# Altium schematic sheet 06-I2C.SchDoc
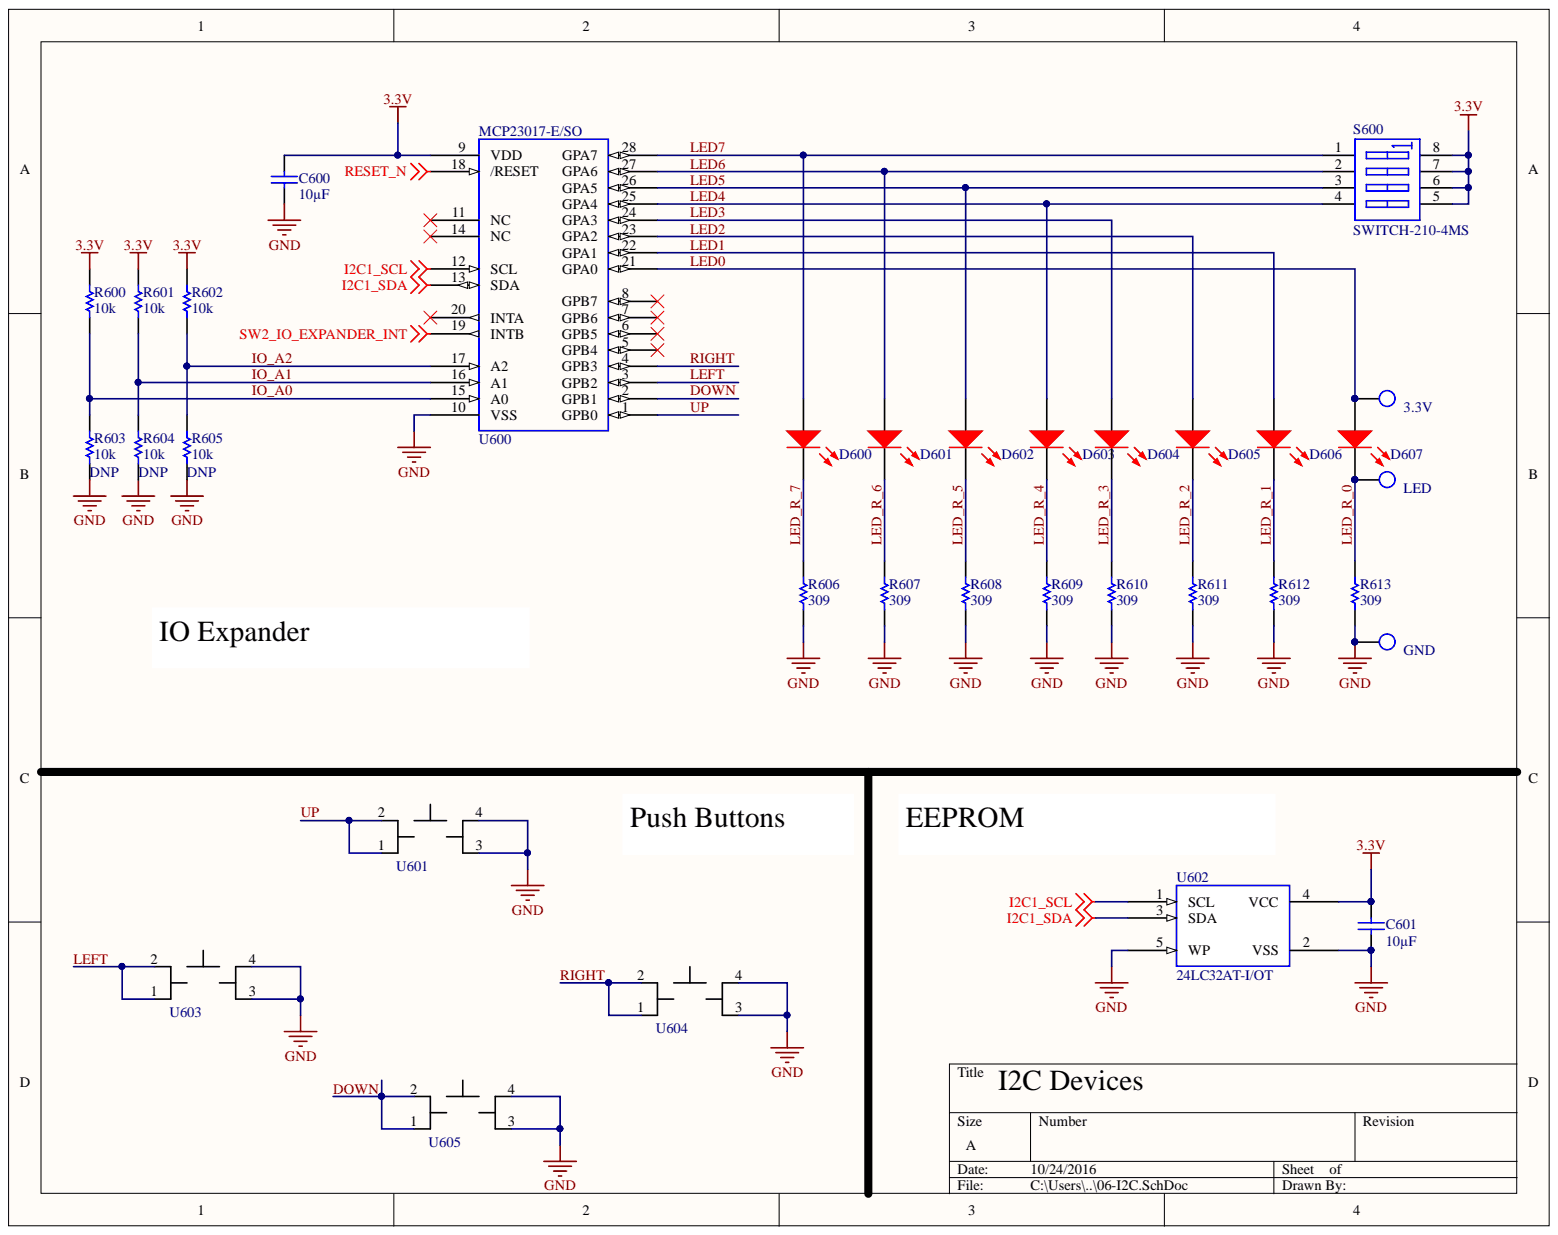
[diagram p0: 06-I2C.SchDoc]

## Parts
C600  10µF  [0805]
C601  10µF  [0805]
D600  LED_RED_0805  [CD2012-0805]  (value not drawn)
D601  LED_RED_0805  [CD2012-0805]  (value not drawn)
D602  LED_RED_0805  [CD2012-0805]  (value not drawn)
D603  LED_RED_0805  [CD2012-0805]  (value not drawn)
D604  LED_RED_0805  [CD2012-0805]  (value not drawn)
D605  LED_RED_0805  [CD2012-0805]  (value not drawn)
D606  LED_RED_0805  [CD2012-0805]  (value not drawn)
D607  LED_RED_0805  [CD2012-0805]  (value not drawn)
R600  10k  [RES_0805]
R601  10k  [RES_0805]
R602  10k  [RES_0805]
R603  10k  Usage: DNP  [RES_0805]
R604  10k  Usage: DNP  [RES_0805]
R605  10k  Usage: DNP  [RES_0805]
R606  309  [RES_0805]
R607  309  [RES_0805]
R608  309  [RES_0805]
R609  309  [RES_0805]
R610  309  [RES_0805]
R611  309  [RES_0805]
R612  309  [RES_0805]
R613  309  [RES_0805]
S600  SWITCH-210-4MS  [DIP-8]
TP600  3.3V  [TP-SMC-1206]
TP601  LED  [TP-SMC-1206]
TP602  GND  [TP-SMC-1206]
U600  Manufacturer Part Number: MCP23017-E/SO  [SOIC-28]  (hidden comment: IC_MCP23017)
U601  SWITCH_6mm_6mm_smd  [SWITCH_6mm_6mm_smd]  (value not drawn)
U602  Manufacturer Part Number: 24LC32AT-I/OT  [SOT23-5-MCP]  (hidden comment: IC_24LC32AT-I/OT)
U603  SWITCH_6mm_6mm_smd  [SWITCH_6mm_6mm_smd]  (value not drawn)
U604  SWITCH_6mm_6mm_smd  [SWITCH_6mm_6mm_smd]  (value not drawn)
U605  SWITCH_6mm_6mm_smd  [SWITCH_6mm_6mm_smd]  (value not drawn)

## Nets
3.3V: C600.2 C601.2 R600.2 R601.2 R602.2 S600.5 S600.6 S600.7 S600.8 U600.9(VDD) U602.4(VCC)
GND: C600.1 C601.1 R603.1 R604.1 R605.1 R606.1 R607.1 R608.1 R609.1 R610.1 R611.1 R612.1 R613.1 TP602.1 U600.10(VSS) U601.3 U601.4 U602.2(VSS) U602.5(WP) U603.3 U603.4 U604.3 U604.4 U605.3 U605.4
I2C1_SCL: U600.12(SCL) U602.1(SCL)
I2C1_SDA: U600.13(SDA) U602.3(SDA)
RESET_N: U600.18(/RESET)
SW2_IO_EXPANDER_INT: U600.19(INTB)
DOWN: U600.2(GPB1) U605.1 U605.2
IO_A0: R600.1 R603.2 U600.15(A0)
IO_A1: R601.1 R604.2 U600.16(A1)
IO_A2: R602.1 R605.2 U600.17(A2)
LED0: D607.1 TP600.1 U600.21(GPA0)
LED1: D606.1 U600.22(GPA1)
LED2: D605.1 U600.23(GPA2)
LED3: D604.1 U600.24(GPA3)
LED4: D603.1 S600.4 U600.25(GPA4)
LED5: D602.1 S600.3 U600.26(GPA5)
LED6: D601.1 S600.2 U600.27(GPA6)
LED7: D600.1 S600.1 U600.28(GPA7)
LED_R_0: D607.2 R613.2 TP601.1
LED_R_1: D606.2 R612.2
LED_R_2: D605.2 R611.2
LED_R_3: D604.2 R610.2
LED_R_4: D603.2 R609.2
LED_R_5: D602.2 R608.2
LED_R_6: D601.2 R607.2
LED_R_7: D600.2 R606.2
LEFT: U600.3(GPB2) U603.1 U603.2
RIGHT: U600.4(GPB3) U604.1 U604.2
UP: U600.1(GPB0) U601.1 U601.2

Not connected: U600 5(GPB4) 6(GPB5) 7(GPB6) 8(GPB7) 11(NC) 14(NC) 20(INTA)

## Library data of the parts
C600 C601 = CAP_0805_U_010.000: CAP CER 10UF 16V 10% X5R 0805; Manufacturer: Murata Electronics North America; Manufacturer Part Number: GRM21BR61C106KE15L; Applications: General Purpose; Capacitance: 10µF; Category: Capacitors; Component Kind: Standard; Features: -; Height - Seated (Max): -; Lead Spacing: -; Lead Style: -; Library Name: ece353-2016.SchLib; Mounting Type: Surface Mount, MLCC; Operating Temperature: -55°C ~ 85°C; Package / Case: 0805 (2012 Metric); Packaging: Cut Tape (CT); Ratings: -; RoHS: RoHS Compliant; Size / Dimension: 0.079" L x 0.049" W (2.00mm x 1.25mm); Supplier: Digi-Key; Supplier 1: Digi-Key; Supplier Part Number: 490-3886-1-ND; Supplier Part Number 1: 490-3886-1-ND; Temperature Coefficient: X5R; Thickness (Max): 0.053" (1.35mm); Tolerance: ±10%; Value: 10µF; Voltage - Rated: 16V
D600-D607 = LED_RED_0805: LED RED CLEAR 0805 SMD; Manufacturer: Kingbright; Manufacturer Part Number: APT3216SRCPRV; Current - Test: 20mA; Lens Color: Colorless; Lens Style/Size: Rectangle with Flat Top, 2.00mm x 1.60mm; Millicandela Rating: 30mcd; Viewing Angle: 120°; Voltage - Forward (Vf) (Typ): 1.85V; Wavelength - Dominant: 640nm; Wavelength - Peak: 655nm; Category: Optoelectronics; Color: Red; Component Kind: Standard; Features: -; Height (Max): 0.75mm; LatestRevisionDate: 17-Jul-2002; LatestRevisionNote: Re-released for DXP Platform.; Lens Transparency: Clear; Library Name: ece353-2016.SchLib; Mounting Type: Surface Mount; Package / Case: 1206 (3216 Metric); PackageDescription: SM LED; 2 Flat Leads; PackageReference: 3.2X1.6X1.1; PackageVersion: Feb-2002; Packaging: Cut Tape (CT); Published: 8-Jun-2000; RoHS: RoHS Compliant; Size / Dimension: 3.20mm L x 1.60mm W; Supplier: Digi-Key; Supplier 1: Digi-Key; Supplier Device Package: Chip LED; Supplier Part Number: 754-1142-1-ND; Supplier Part Number 1: 754-1097-1-ND; Publisher: Altium Limited
R600-R605 = RES_0805_K_010.00: RES 10K 1/10W 1% 0805 SMD; Manufacturer: Panasonic Electronic Components; Manufacturer Part Number: ERJ-6ENF1002V; Power (Watts): 0.125W, 1/8W; Temperature Coefficient: ±100ppm/°C; Category: Resistors; Component Kind: Standard; Composition: Thick Film; Features: Automotive AEC-Q200; Height: 0.028" (0.70mm); Library Name: ece353-2016.SchLib; Number of Terminations: 2; Operating Temperature: -55°C ~ 155°C; Package / Case: 0805 (2012 Metric); Packaging: Cut Tape (CT); Resistance: 10k; RoHS: RoHS Compliant; Size / Dimension: 0.079" L x 0.049" W (2.00mm x 1.25mm); Supplier: Digi-Key; Supplier 1: Digi-Key; Supplier Device Package: 0805; Supplier Part Number: P10.0KCCT-ND; Supplier Part Number 1: P10.0KCCT-ND; Tolerance: ±1%; Value: 10k
R606-R613 = RES_0805_309.00: RES 309 OHM 1% 1/8W 0805; Manufacturer: Panasonic Electronic Components; Manufacturer Part Number: ERJ-6ENF3090V; Power (Watts): 0.125W, 1/8W; Temperature Coefficient: ±100ppm/°C; Category: Resistors; Component Kind: Standard; Composition: Thick Film; Features: Automotive AEC-Q200; Height: 0.028" (0.70mm); Library Name: ece353-2016.SchLib; Number of Terminations: 2; Operating Temperature: -55°C ~ 155°C; Package / Case: 0805 (2012 Metric); Packaging: Cut Tape (CT); Resistance: 309; RoHS: RoHS Compliant; Size / Dimension: 0.079" L x 0.049" W (2.00mm x 1.25mm); Supplier: Digi-Key; Supplier 1: Digi-Key; Supplier Device Package: 0805; Supplier Part Number: P309CCT-ND; Supplier Part Number 1: P309CCT-ND; Tolerance: ±1%; Value: 309
S600 = SWITCH-210-4MS: DIP Switch, 4 Position, SPST; Manufacturer: CTS Electrocomponents; Actuator Level: Raised; Actuator Type: Slide (Standard); Current Rating: 100mA; Electrical Life: 1,000 Cycles; Number of Positions: 4; Pitch: 0.100" (2.54mm), Full; Voltage Rating: 20VDC; Washable: No; Body Material: -; Category: Switches; Circuit: SPST; Code_IPC: SOIC127P600-8; Code_JEDEC: MS-012-AA; Component Kind: Standard; Contact Finish: Gold; Contact Material: Beryllium Copper; Features: Epoxy Sealed Terminals; Height Above Board: 0.190" (4.83mm); LatestRevisionDate: 29-May-2009; LatestRevisionNote: IPC-7351 Footprint Added.; Length: 0.458" (11.63mm); Library Name: ece353-2016.SchLib; Manufacturer Part Number: 210-4MS; Mechanical Life: -; Mounting Type: Through Hole; Operating Temperature: -40°C ~ 85°C; PackageDescription: 8-Pin Small Outline Integrated Circuit 1.27 mm Pitch; PackageReference: SO8; PackageVersion: Dec-2002; Packaging: Tube; Published: 24-Mar-1999; RoHS: RoHS Compliant; Supplier: Digi-Key; Supplier 1: Digi-Key; Supplier Part Number: CT2104MS-ND; Supplier Part Number 1: CT2104MS-ND; Termination Style: PC Pin; Publisher: Altium Limited
TP600-TP602 = TP_1206: Test Point SMD 1206; Component Kind: Standard; Library Name: ece353-2016.SchLib; Supplier 1: Digi-Key; Supplier Part Number 1: A106143CT-ND
U600 = IC_MCP23017: I2C 16-bit IO Expander; Manufacturer: Microchip Technology; Current - Output Source/Sink: 25mA; Frequency - Clock: 1.7MHz; Interface: I²C; Interrupt Output: Yes; Number of I/O: 16; Output Type: Push-Pull; Voltage - Supply: 1.8 V ~ 5.5 V; Category: Integrated Circuits (ICs); Component Kind: Standard; Features: POR; Library Name: ece353-2016.SchLib; Mounting Type: Surface Mount; Operating Temperature: -40°C ~ 125°C; Package / Case: 28-SOIC (0.295", 7.50mm Width); Packaging: Tube; RoHS: RoHS Compliant; Supplier 1: Digi-Key; Supplier Device Package: 28-SOIC; Supplier Part Number 1: MCP23017-E/SO-ND
U601 U603-U605 = SWITCH_6mm_6mm_smd: SWITCH TACTILE SPST-NO 0.05A 12V; Manufacturer: C&K Components; Manufacturer Part Number: PTS645SH50SMTR92 LFS; Actuator Height off PCB, from Pins: 5.00mm; Actuator Material: Polyamide (PA66), Nylon 6/6; Actuator Orientation: Top Actuated; Actuator Type: Standard; Contact Rating @ Voltage: 0.05A @ 12VDC; Illumination: Non-Illuminated; Mechanical Life: 100,000 Cycles; Operating Force: 200gf; Outline: 6.00mm x 6.00mm; Switch Function: Off-Mom; Category: Switches; Circuit: SPST-NO; Component Kind: Standard; Features: -; Illumination Type, Color: -; Illumination Voltage (Nominal): -; Ingress Protection: -; Library Name: ece353-2016.SchLib; Mounting Type: Surface Mount; Operating Temperature: -20°C ~ 60°C; Packaging: Tape & Reel (TR); RoHS: RoHS Compliant; Supplier: Digi-Key; Supplier 1: Digi-Key; Supplier Part Number: CKN9085TR-ND; Supplier Part Number 1: CKN9085CT-ND; Switch Travel: -; Termination Style: Gull Wing
U602 = IC_24LC32AT-I/OT: IC EEPROM 32KBIT 400KHZ SOT23-5; Manufacturer: Microchip Technology; Component Kind: Standard; Library Name: ece353-2016.SchLib; Supplier 1: Digi-Key; Supplier Part Number 1: 24LC32AT-I/OTCT-ND
